# Altium schematic sheet POWER.SchDoc
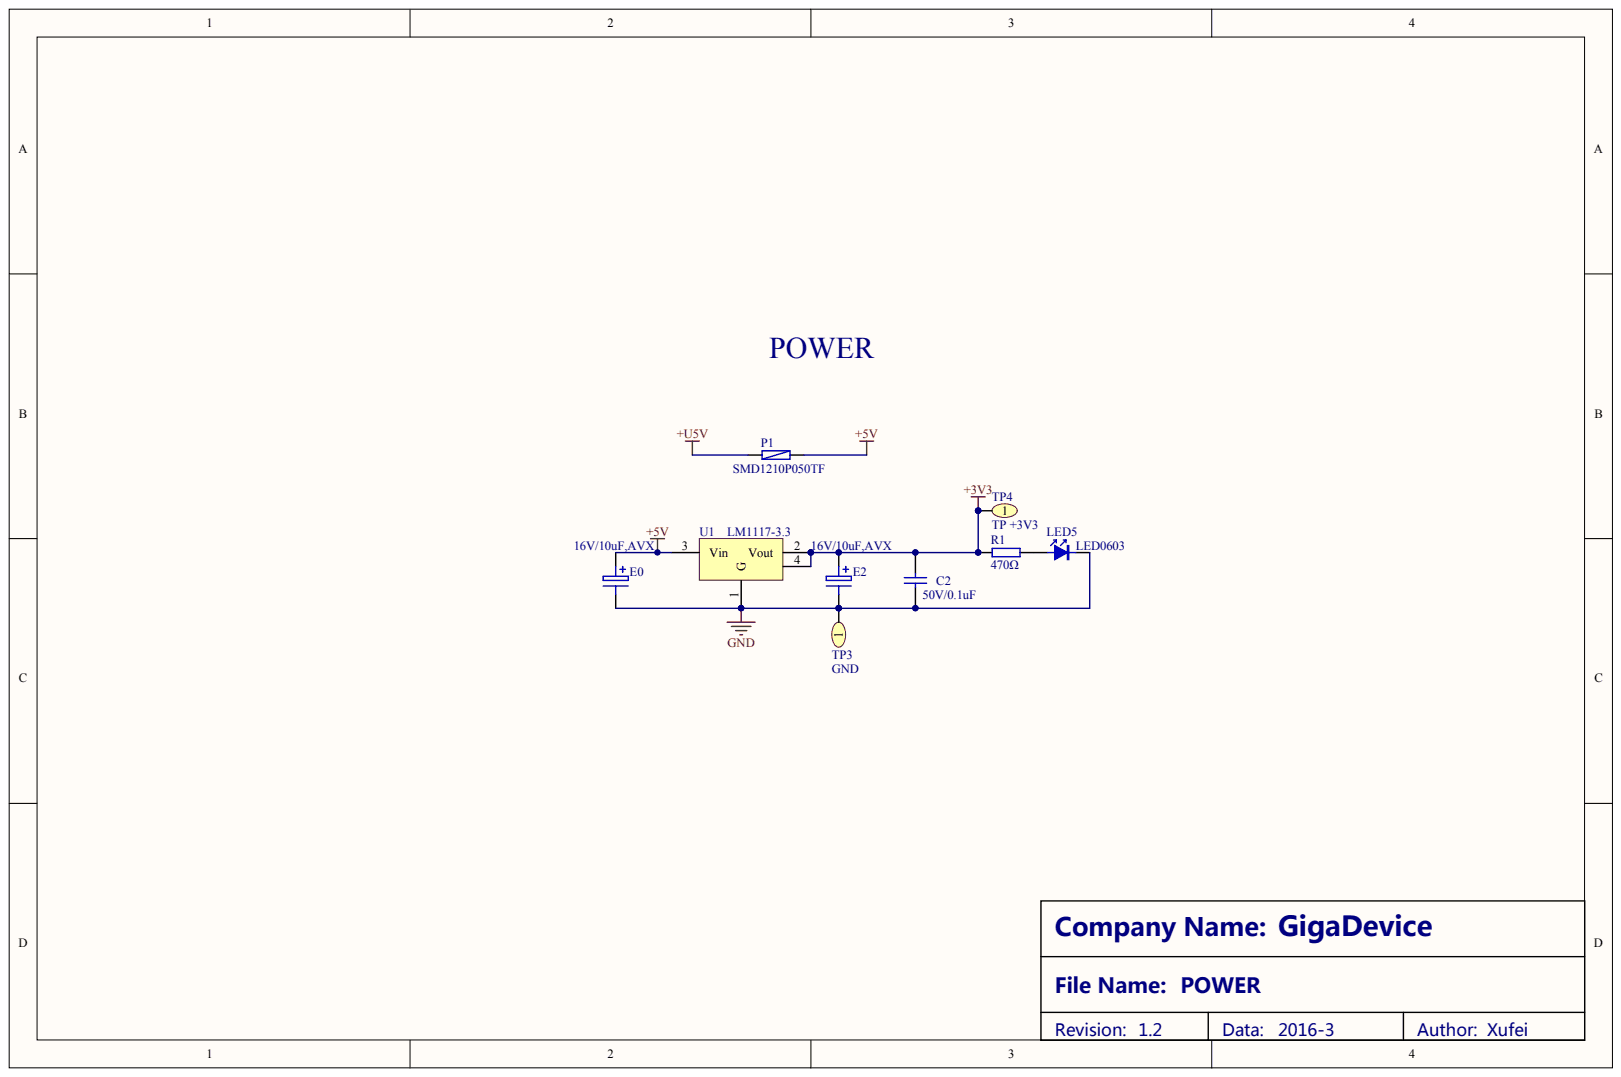
[diagram p0: POWER.SchDoc]

## Parts
C2  50V/0.1uF
E0  16V/10uF,AVX
E2  16V/10uF,AVX
LED5  LED0603
P1  SMD1210P050TF
R1  470Ω
TP3  GND
TP4  TP +3V3
U1  LM1117-3.3

## Nets
+3V3: C2.2 E2.1 R1.1 TP4.1 U1.2(Vout) U1.4
+5V: E0.1 P1.2 U1.3(Vin)
+U5V: P1.1
GND: C2.1 E0.2 E2.2 LED5.K TP3.1 U1.1(G)
NetLED5_A: LED5.A R1.2
